# Altium schematic sheet Application_Debug.SchDoc(Application_Debug)
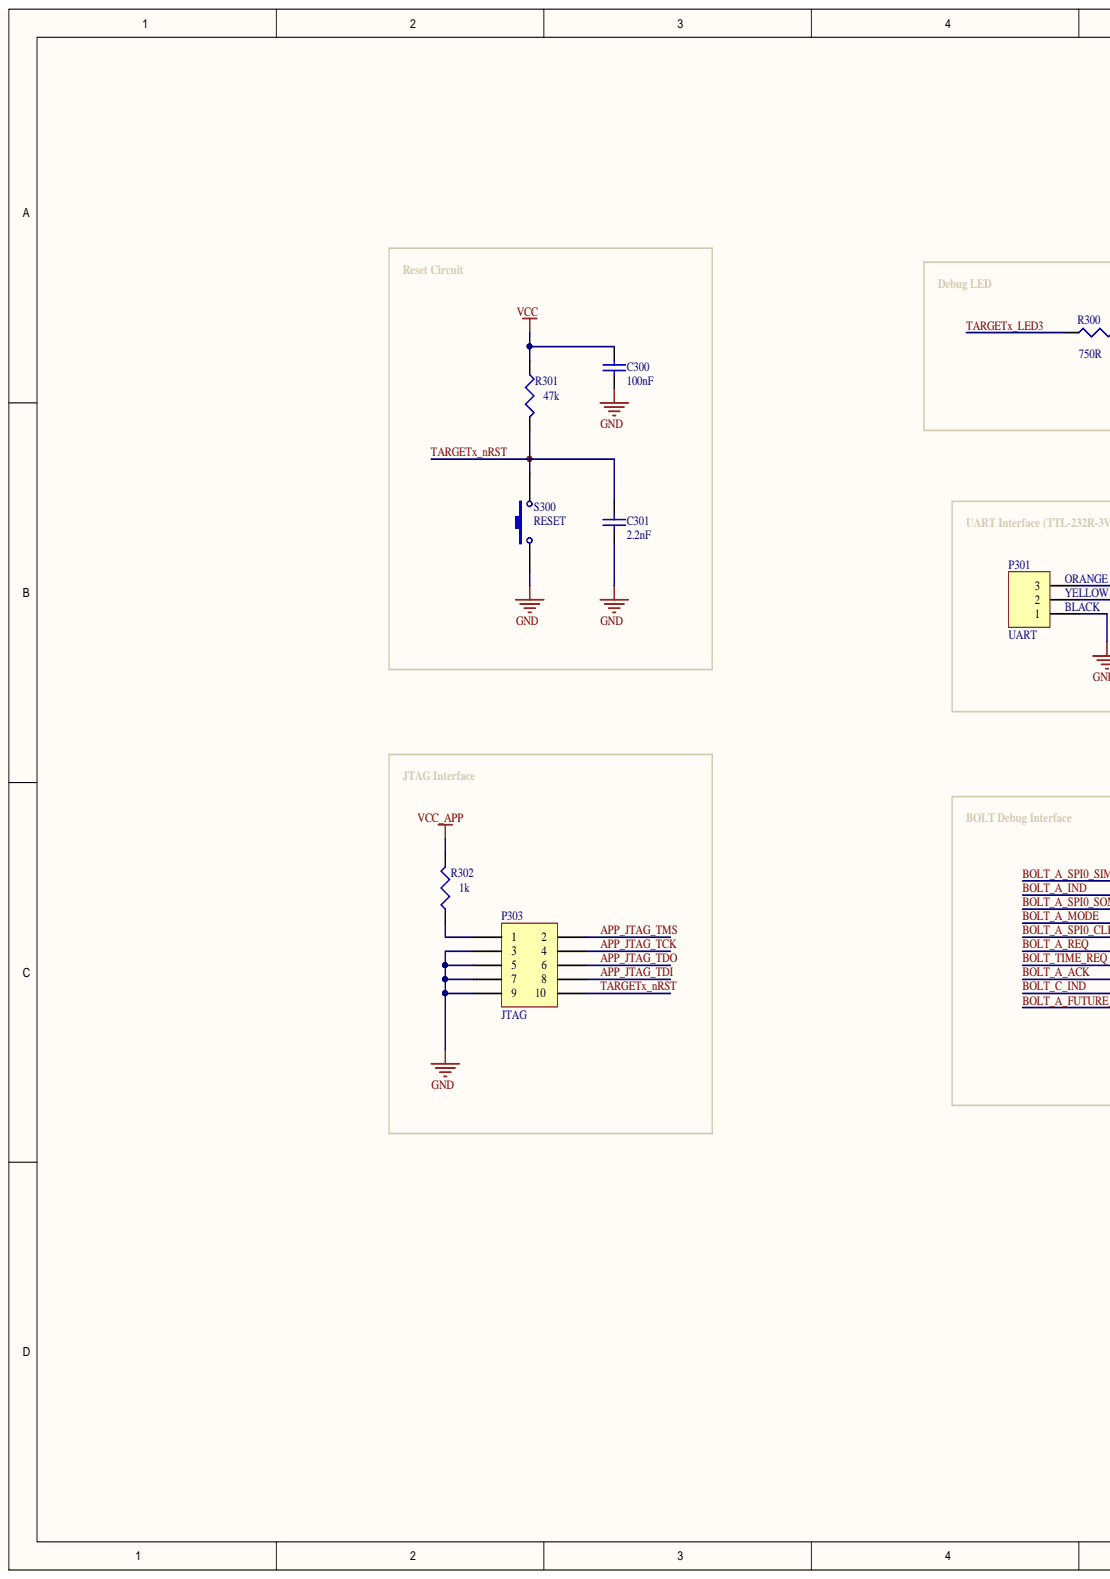
[diagram p0: Application_Debug.SchDoc, left]
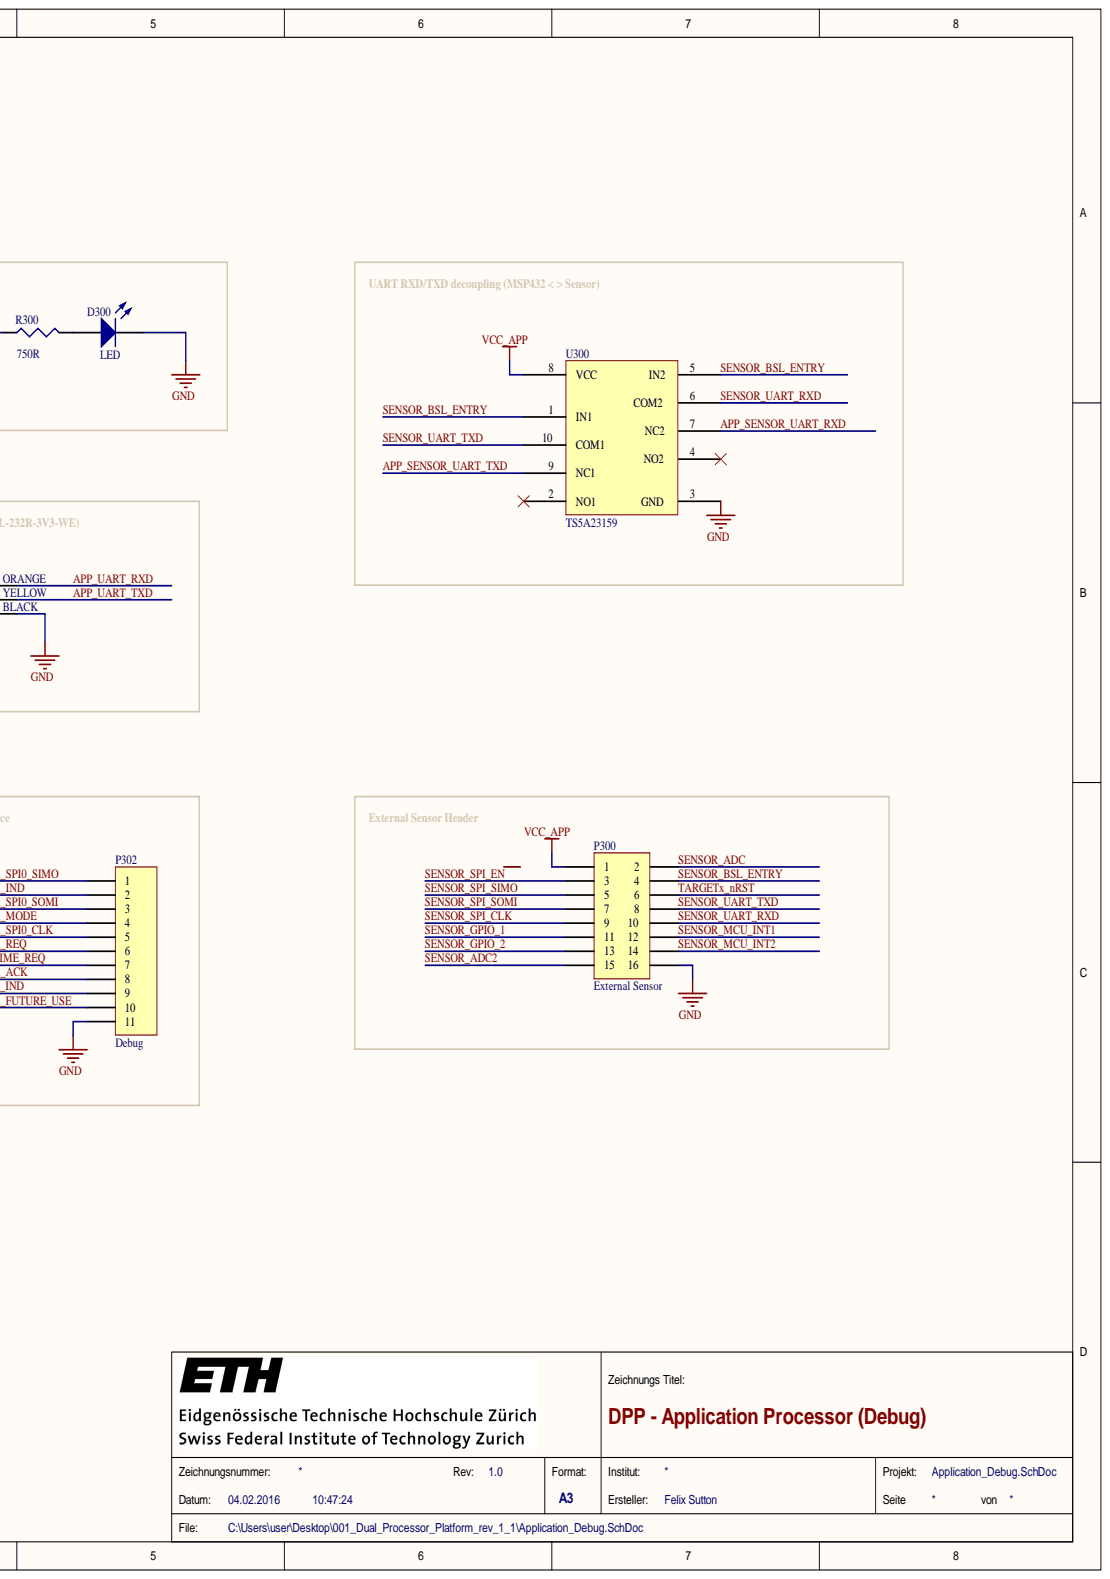
[diagram p1: Application_Debug.SchDoc, right]

## Parts
C300  100nF  [CAPC1608N]
C301  2.2nF  [CAPC1608N]
D300  LED  [CD2012-0805]
P300  External Sensor  [HDR2X8]
P301  UART  [HDR1X3]
P302  Debug  [HDR1X11]
P303  JTAG  [HDR2X5_127]
R300  750R  [RESC1608N]
R301  47k  [RESC1608N]
R302  1k  [RESC1608N]
S300  RESET  [TL3302]
U300  TS5A23159  [PUQFN_N10]

## Nets
GND: C300.1 C301.1 D300.2 P300.16 P301.1 P302.11 P303.3 P303.5 P303.7 P303.9 S300.1 U300.3(GND)
VCC: C300.2 R301.1
VCC_APP: P300.1 R302.2 U300.8(VCC)
APP_JTAG_TCK: P303.4
APP_JTAG_TDI: P303.8
APP_JTAG_TDO: P303.6
APP_JTAG_TMS: P303.2
APP_SENSOR_UART_RXD: U300.7(NC2)
APP_SENSOR_UART_TXD: U300.9(NC1)
APP_UART_RXD: P301.3
APP_UART_TXD: P301.2
BOLT_A_ACK: P302.8
BOLT_A_FUTURE_USE: P302.10
BOLT_A_IND: P302.2
BOLT_A_MODE: P302.4
BOLT_A_REQ: P302.6
BOLT_A_SPI0_CLK: P302.5
BOLT_A_SPI0_SIMO: P302.1
BOLT_A_SPI0_SOMI: P302.3
BOLT_C_IND: P302.9
BOLT_TIME_REQ: P302.7
SENSOR_ADC: P300.2
SENSOR_ADC2: P300.15
SENSOR_BSL_ENTRY: P300.4 U300.1(IN1) U300.5(IN2)
SENSOR_GPIO_1: P300.11
SENSOR_GPIO_2: P300.13
SENSOR_MCU_INT1: P300.12
SENSOR_MCU_INT2: P300.14
SENSOR_SPI_CLK: P300.9
SENSOR_SPI_~{EN}: P300.3
SENSOR_SPI_SIMO: P300.5
SENSOR_SPI_SOMI: P300.7
SENSOR_UART_RXD: P300.10 U300.6(COM2)
SENSOR_UART_TXD: P300.8 U300.10(COM1)
TARGETX_LED3: R300.1
TARGETX_NRST: C301.2 P300.6 P303.10 R301.2 S300.2
NetD300_1: D300.1 R300.2
NetP303_1: P303.1 R302.1

Not connected: U300 2(NO1) 4(NO2)

## Library data of the parts
C300 C301 = Cap: Capacitor; Component Kind: Standard; LatestRevisionDate: 17-Jul-2002; LatestRevisionNote: Re-released for DXP Platform.; Library Name: Miscellaneous Devices.IntLib; PackageReference: RAD-0.3; Published: 8-Jun-2000; Publisher: Altium Limited
D300 = LED0: Typical INFRARED GaAs LED; Component Kind: Standard; LatestRevisionDate: 08-Jul-2005; LatestRevisionNote: Mech Layer 1 removed.; Library Name: Miscellaneous Devices.IntLib; PackageReference: LED-0; Published: 8-Jun-2000; Publisher: Altium Limited
P300 = Header 8X2: Header, 8-Pin, Dual row; Component Kind: Standard; LatestRevisionDate: 17-Jul-2002; LatestRevisionNote: Re-released for DXP Platform.; Library Name: Miscellaneous Connectors.IntLib; Signal Integrity: Connector; Publisher: Altium Limited
P301 = Header 3: Header, 3-Pin; Component Kind: Standard; LatestRevisionDate: 17-Jul-2002; LatestRevisionNote: Re-released for DXP Platform.; Library Name: Miscellaneous Connectors.IntLib; Signal Integrity: Connector; Publisher: Altium Limited
P302 = Header 11: Header, 11-Pin; Component Kind: Standard; LatestRevisionDate: 17-Jul-2002; LatestRevisionNote: Re-released for DXP Platform.; Library Name: Miscellaneous Connectors.IntLib; Signal Integrity: Connector; Publisher: Altium Limited
P303 = Header 5X2: Header, 5-Pin, Dual row; Component Kind: Standard; LatestRevisionDate: 17-Jul-2002; LatestRevisionNote: Re-released for DXP Platform.; Library Name: Miscellaneous Connectors.IntLib; Signal Integrity: Connector; Publisher: Altium Limited
R300-R302 = Res3: Resistor; Component Kind: Standard; LatestRevisionDate: 29-May-2009; LatestRevisionNote: IPC-7351 Footprint Added.; Library Name: Miscellaneous Devices.IntLib; PackageDescription: Chip Resistor; PackageReference: J1-0603; Published: 8-Jun-2000; Publisher: Altium Limited
S300 = SW-PB: Switch; Component Kind: Standard; LatestRevisionDate: 17-Jul-2002; LatestRevisionNote: Re-released for DXP Platform.; Library Name: Miscellaneous Devices.IntLib; PackageReference: SPST-2; Published: 24-Mar-1999; Publisher: Altium Limited
U300 = TS5A23159: TI Dual SPDT Analog Switch; Component Kind: Standard; Library Name: Felix_Component_Library.SchLib
